# Altium schematic sheet 02-Mezzanine.SchDoc
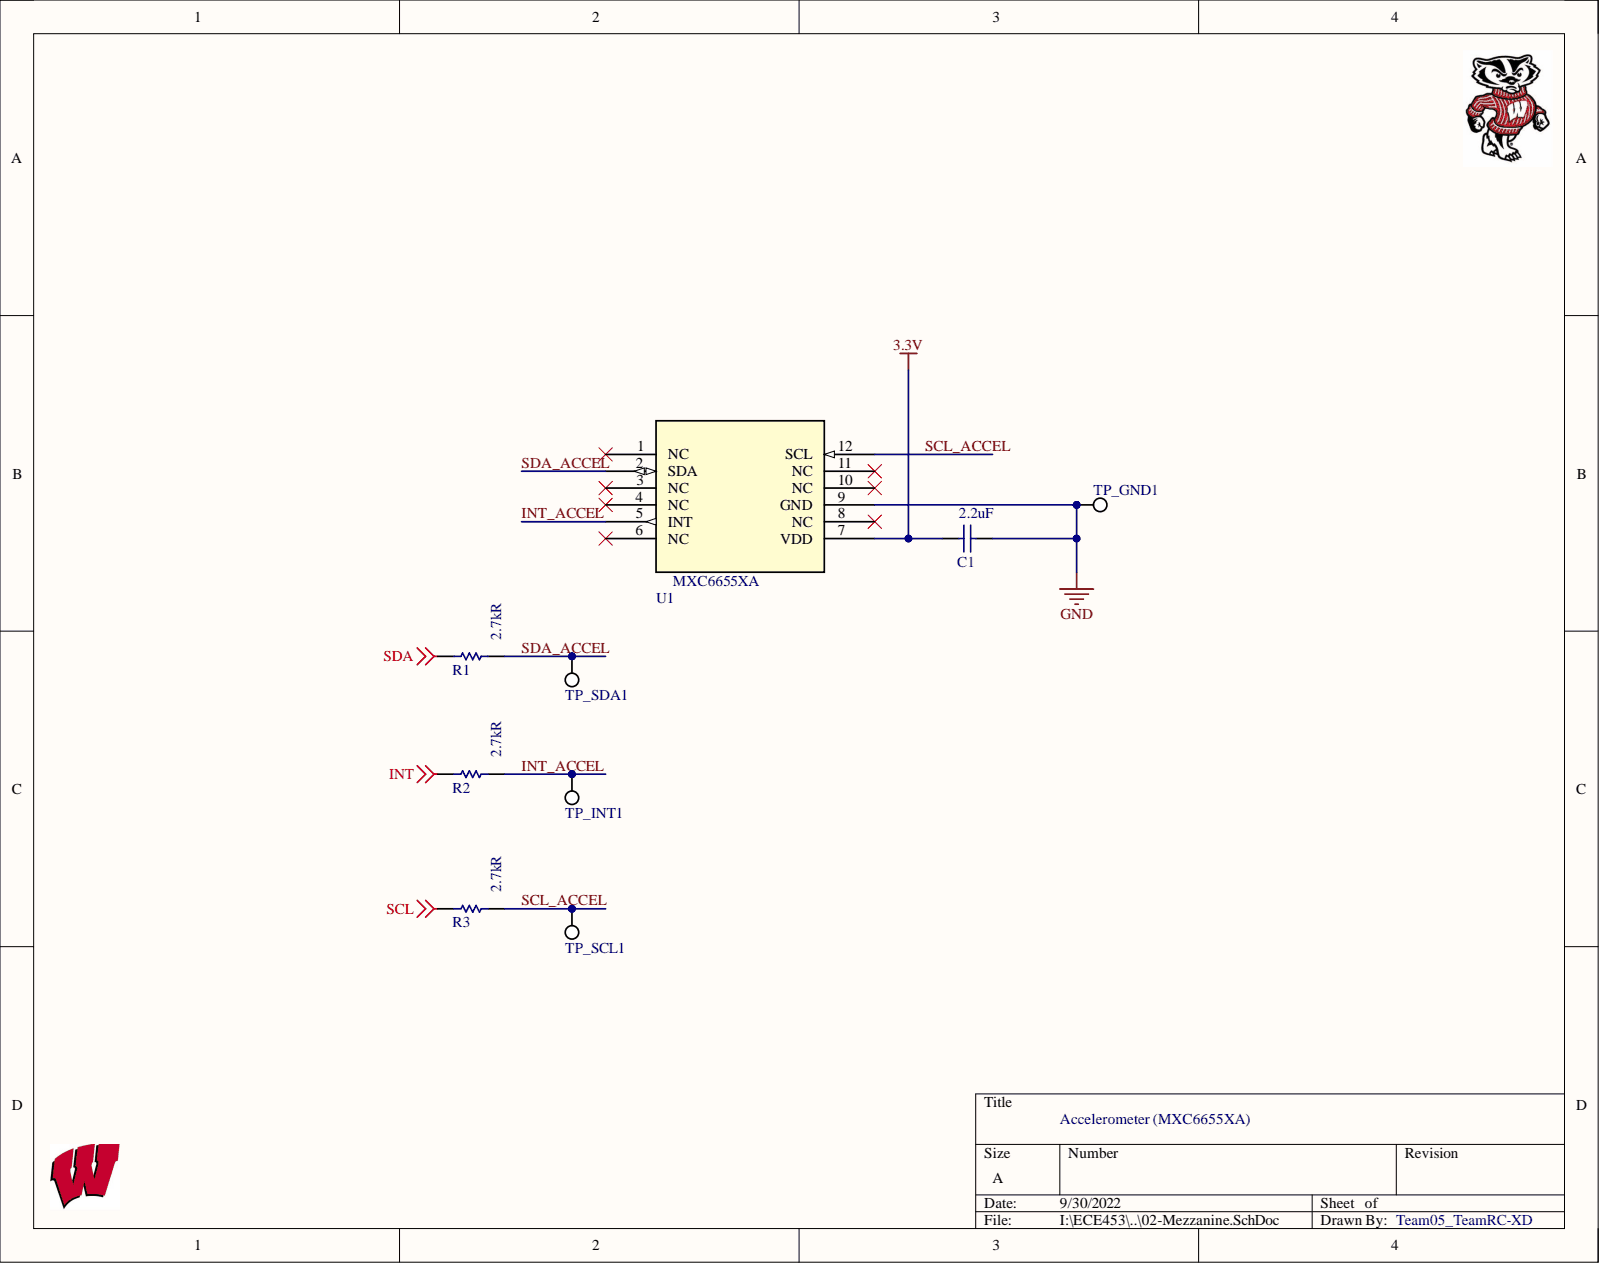
[diagram p0: 02-Mezzanine.SchDoc]

## Parts
C1  CAP_0805_U_2_2  [CAPC2012X135N]  (value not drawn)
R1  RES_0805_2_7K_OHM  [RESC2012X65N]  (value not drawn)
R2  RES_0805_2_7K_OHM  [RESC2012X65N]  (value not drawn)
R3  RES_0805_2_7K_OHM  [RESC2012X65N]  (value not drawn)
TP_GND1  5019  [KSTN-5019_V]  (value not drawn)
TP_INT1  5019  [KSTN-5019_V]  (value not drawn)
TP_SCL1  5019  [KSTN-5019_V]  (value not drawn)
TP_SDA1  5019  [KSTN-5019_V]  (value not drawn)
U1  MXC6655XA  [IC_MXC6655XA]

## Nets
3.3V: C1.1 U1.7(VDD)
GND: C1.2 TP_GND1.1 U1.9(GND)
INT: R2.2
SCL: R3.2
SDA: R1.2
INT_ACCEL: R2.1 TP_INT1.1 U1.5(INT)
SCL_ACCEL: R3.1 TP_SCL1.1 U1.12(SCL)
SDA_ACCEL: R1.1 TP_SDA1.1 U1.2(SDA)

Not connected: U1 1(NC) 3(NC) 4(NC) 6(NC) 8(NC) 10(NC) 11(NC)

## Library data of the parts
C1 = CAP_0805_U_2_2: 2.2 micro farad capacitor; Component Kind: Standard; Library Name: ECE453-F22-Power.SCHLIB
R1-R3 = RES_0805_2_7K_OHM: 2.7 KOhm resistor; Component Kind: Standard; Library Name: ECE453-F22-Power.SCHLIB
TP_GND1 TP_INT1 TP_SCL1 TP_SDA1 = CMP-1672-00008-1: Test Point, 1 Position SMD, RoHS, Tape and Reel; Component Kind: Standard; ComponentLink1Description: Manufacturer URL; ComponentLink1URL: http://www.keyelco.com/; ComponentLink2Description: Datasheet; ComponentLink2URL: http://www.keyelco.com/product-pdf.cfm?p=1357; Contact Plating: Silver; DatasheetVersion: 03/2014; Library Name: Altium Content Vault; Mount: Surface Mount; Mounting Technology: Surface Mount; Package Quantity: 1000; PackageDescription: 1-Pin Surface Mount Device, Body 3.81 x 2.03 mm; PackageReference: 5019; Packaging: Tape and Reel; Pins: 1; RoHSCompliant: True
U1 = MXC6655XA: Aceelerometer; Component Kind: Standard; Library Name: ECE453-F22-Power.SCHLIB
